# Altium schematic sheet [04] Flash_Fram.SchDoc
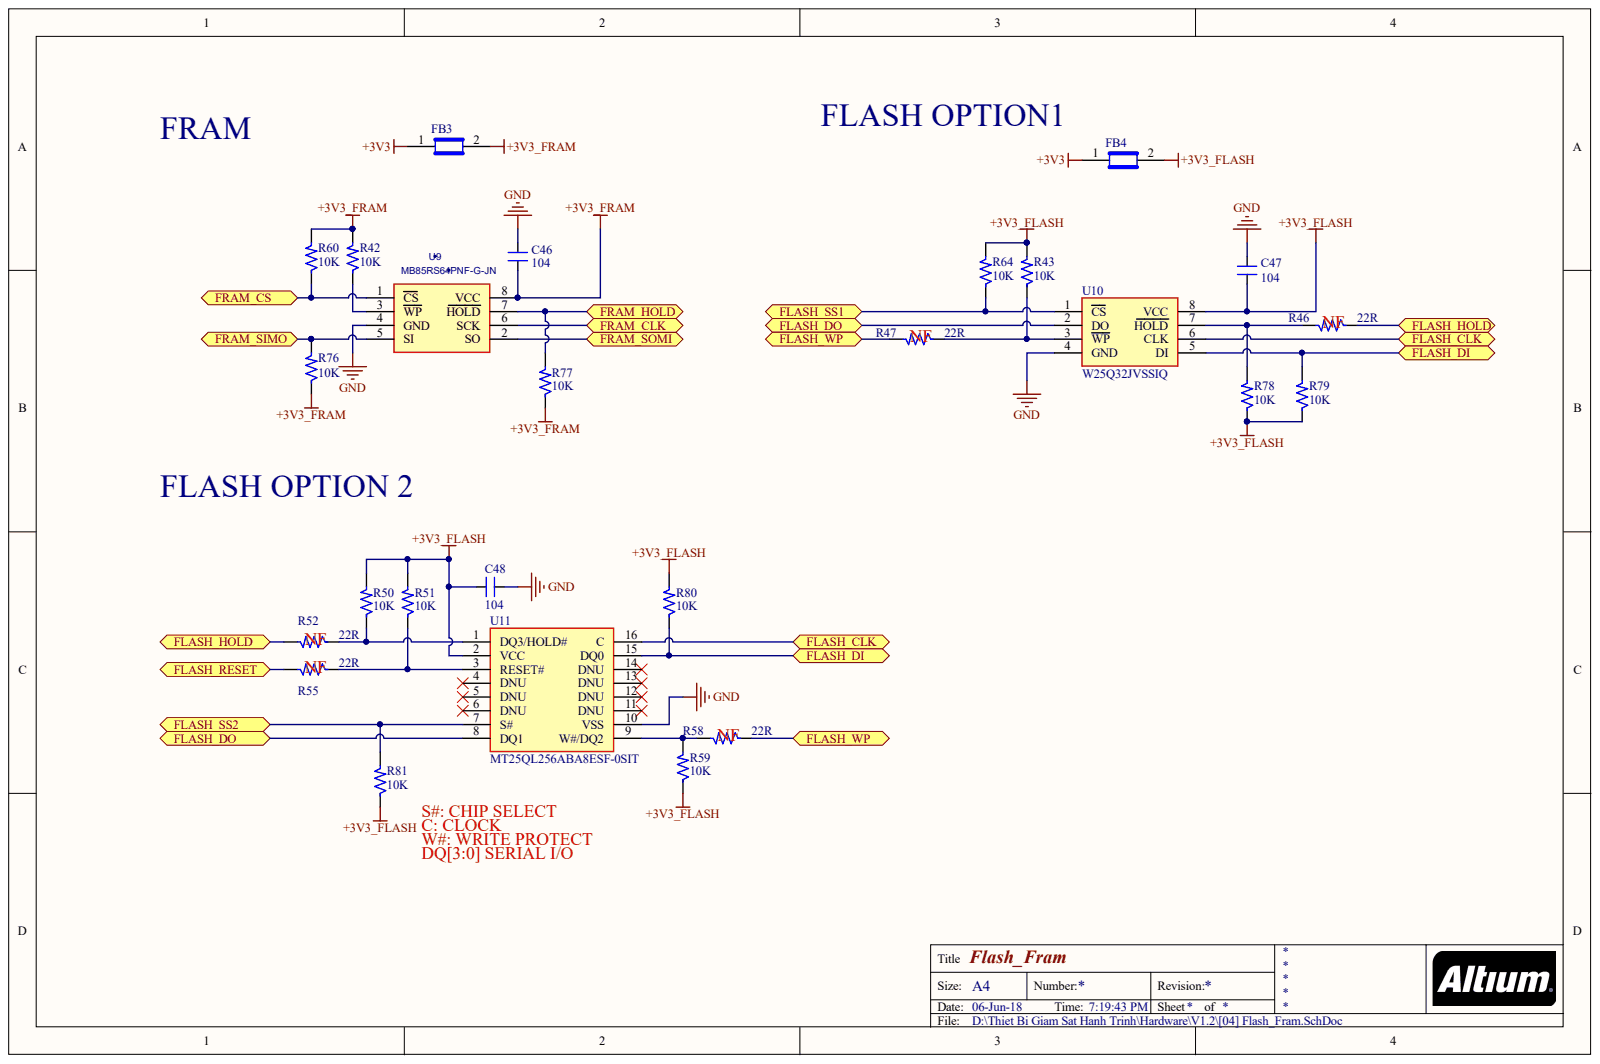
[diagram p0: [04] Flash_Fram.SchDoc]

## Parts
C46  104  [C0603 SMT]
C47  104  [C0603 SMT]
C48  104  [C0603 SMT]
FB3  BLM21PG600SN1D  [R0805]  (value not drawn)
FB4  BLM21PG600SN1D  [R0805]  (value not drawn)
R42  10K  [R0603 -SMT]
R43  10K  [R0603 -SMT]
R46  22R  [R0603 -SMT]
R47  22R  [R0603 -SMT]
R50  10K  [R0603 -SMT]
R51  10K  [R0603 -SMT]
R52  22R  [R0603 -SMT]
R55  22R  [R0603 -SMT]
R58  22R  [R0603 -SMT]
R59  10K  [R0603 -SMT]
R60  10K  [R0603 -SMT]
R64  10K  [R0603 -SMT]
R76  10K  [R0603 -SMT]
R77  10K  [R0603 -SMT]
R78  10K  [R0603 -SMT]
R79  10K  [R0603 -SMT]
R80  10K  [R0603 -SMT]
R81  10K  [R0603 -SMT]
U9  MB85RS64PNF-G-JN  [FPT-8P-M02-M]
U10  W25Q32JVSSIQ  [SO-8 208]
U11  MT25QL256ABA8ESF-0SIT  [16-SOP2]

## Ports
FLASH_CLK, FLASH_DI, FLASH_DO, FLASH_HOLD, FLASH_RESET, FLASH_SS1, FLASH_SS2, FLASH_WP, FRAM_CLK, FRAM_CS, FRAM_HOLD, FRAM_SIMO, FRAM_SOMI

## Nets
+3V3: FB3.1 FB4.1
+3V3_FLASH: C47.1 C48.2 FB4.2 R43.2 R50.2 R51.2 R59.1 R64.2 R78.1 R79.1 R80.2 R81.1 U10.8(VCC) U11.2(VCC)
+3V3_FRAM: C46.1 FB3.2 R42.2 R60.2 R76.1 R77.1 U9.8(VCC)
GND: C46.2 C47.2 C48.1 U9.4(GND) U10.4(GND) U11.10(VSS)
FLASH_CLK: U10.6(CLK) U11.16(C) ; port FLASH_CLK
FLASH_DI: R79.2 R80.1 U10.5(DI) U11.15(DQ0) ; port FLASH_DI
FLASH_DO: U10.2(DO) U11.8(DQ1) ; port FLASH_DO
FLASH_HOLD: R46.1 R52.2 ; port FLASH_HOLD
FLASH_RESET: R55.2 ; port FLASH_RESET
FLASH_SS1: R64.1 U10.1(CS) ; port FLASH_SS1
FLASH_SS2: R81.2 U11.7(S#) ; port FLASH_SS2
FLASH_WP: R47.2 R58.1 ; port FLASH_WP
FRAM_CLK: U9.6(SCK) ; port FRAM_CLK
FRAM_CS: R60.1 U9.1(CS) ; port FRAM_CS
FRAM_HOLD: R77.2 U9.7(HOLD) ; port FRAM_HOLD
FRAM_SIMO: R76.2 U9.5(SI) ; port FRAM_SIMO
FRAM_SOMI: U9.2(SO) ; port FRAM_SOMI
NetR42_1: R42.1 U9.3(WP)
NetR43_1: R43.1 R47.1 U10.3(WP)
NetR46_2: R46.2 R78.2 U10.7(HOLD)
NetR50_1: R50.1 R52.1 U11.1(DQ3/HOLD#)
NetR51_1: R51.1 R55.1 U11.3(RESET#)
NetR58_2: R58.2 R59.2 U11.9(W#/DQ2)

Not connected: U11 4(DNU) 5(DNU) 6(DNU) 11(DNU) 12(DNU) 13(DNU) 14(DNU)

## Library data of the parts
C46-C48 = CM: Cap SMD; Value: 103; Component Kind: Standard; Library Name: khapham.IntLib
FB3 FB4 = Ferrite Bead: Component Kind: Standard; Description: Ferrite Bead; Library Name: khapham.IntLib
R42 R43 R46 R47 R50-R52 R55 R58-R60 R64 R76-R81 = RM: Resistor SMD; Value: 1K; Component Kind: Standard; Library Name: khapham.IntLib
U9 = MB85RS64PNF-G-JN: FRAM 64KBIT 20MHZ 8SOP; Manufacturer_Part_Number: MB85RS64PNF-G-JNE1; Built_By: EMA_UL_Team; C: Copyright (C) 2015 Accelerated Designs. All rights reserved; Vendor: Fujitsu Semiconductor; Component Kind: Standard; Value: MB85RS64PNF-G-JN; Library Name: khapham.IntLib
U10 = W25Q32JV: FLASH 32MBIT 133MHZ 8SOP; Component Kind: Standard; Library Name: khapham.IntLib
U11 = MT25QL256ABA: FLASH 256MBIT 133MHZ 16SOIC; Component Kind: Standard; Library Name: khapham.IntLib
